# Altium schematic sheet lv_mcu_ios_100.SchDoc
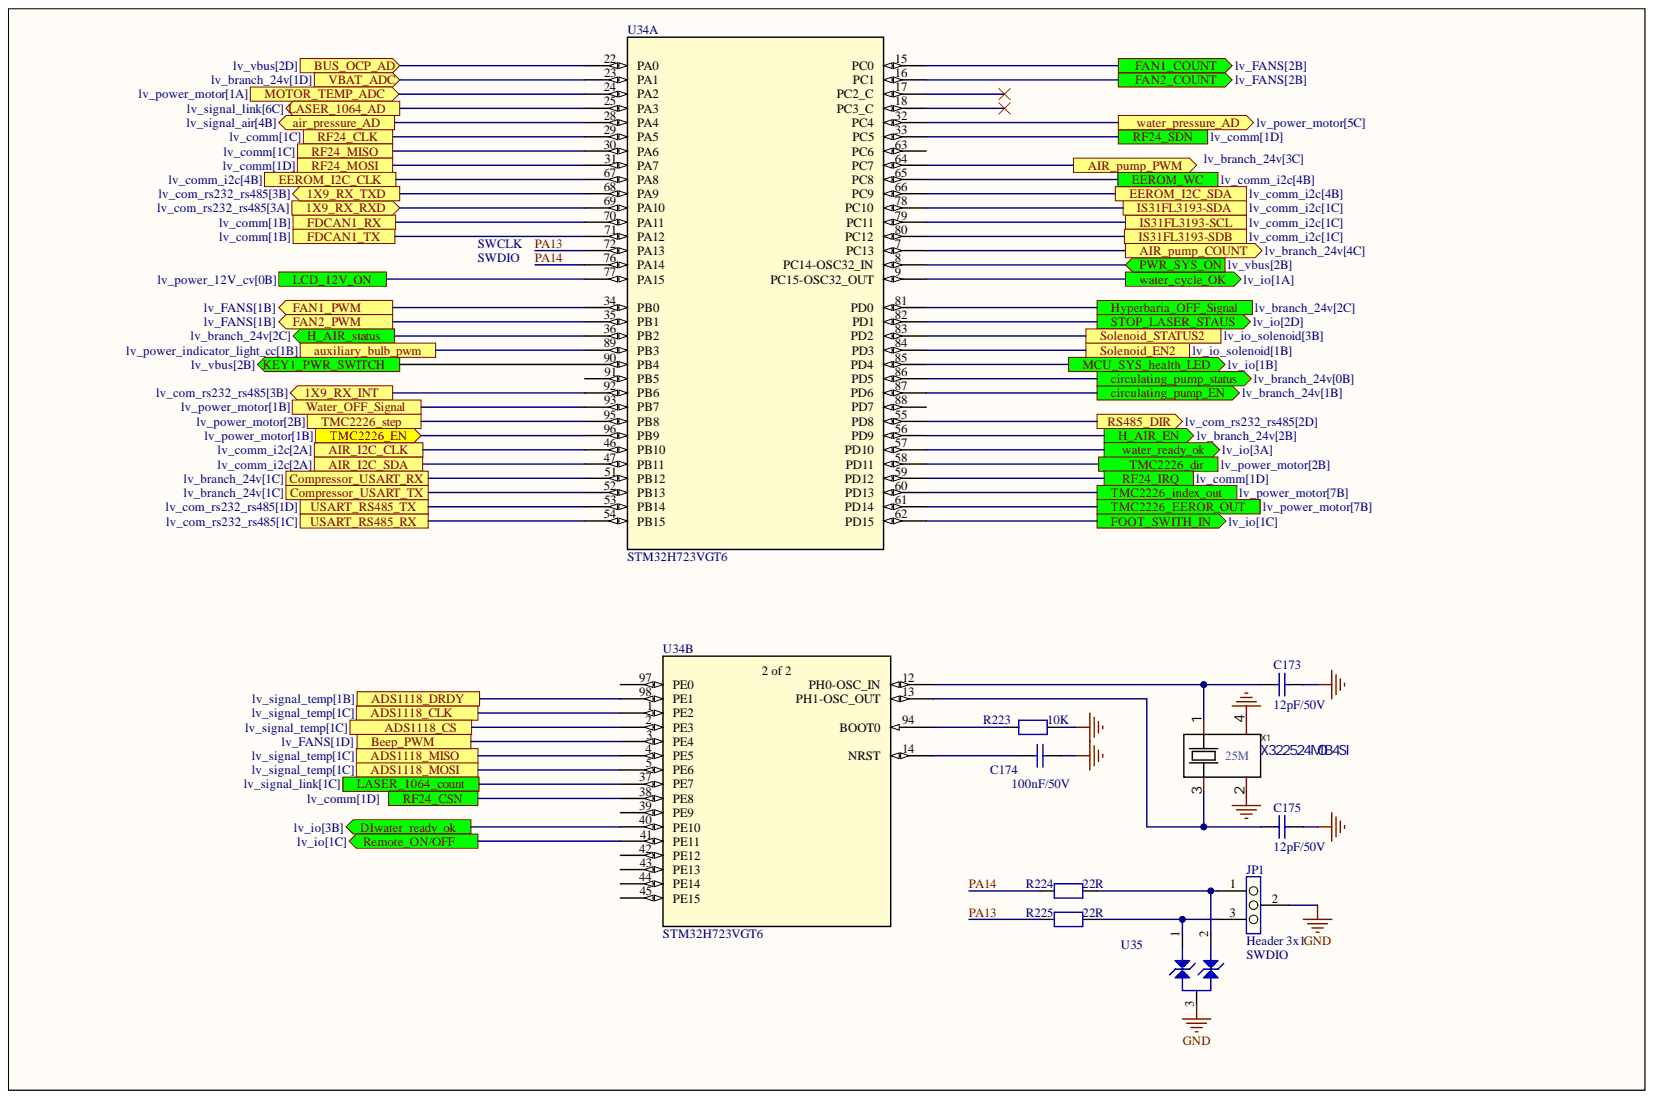
[diagram p0: lv_mcu_ios_100.SchDoc]

## Parts
C173  12pF/50V  [C0402]
C174  100nF/50V  [C0402]
C175  12pF/50V  [C0402]
JP1  value Header 3x1  [CON_SAMTEC_TSW-103-07-F-S]  (hidden comment: Jumper_Header 3x1)
R223  10K  [R0402]
R224  22R  [R0402]
R225  22R  [R0402]
U34  STM32H723VGT6  [LQFP100_STM-L]  (parts A,B)
U35  ESDCAN03-2BWY  [DIO_SOT323]  (value not drawn)
X1  X322524MOB4SI  [CRYSTAL-SMD_4P-L3.2-W2.5-BL]

## Ports
1X9_RX_INT, 1X9_RX_RXD, 1X9_RX_TXD, ADS1118_CLK, ADS1118_CS, ADS1118_DRDY, ADS1118_MISO, ADS1118_MOSI, AIR_I2C_CLK, AIR_I2C_SDA, air_pressure_AD, AIR_pump_COUNT, AIR_pump_PWM, auxiliary_bulb_pwm, Beep_PWM, BUS_OCP_AD, circulating_pump_EN, circulating_pump_status, Compressor_USART_RX, Compressor_USART_TX, DIwater_ready_ok, EEROM_I2C_CLK, EEROM_I2C_SDA, EEROM_WC, FAN1_COUNT, FAN1_PWM, FAN2_COUNT, FAN2_PWM, FDCAN1_RX, FDCAN1_TX, FOOT_SWITH_IN, H_AIR_EN, H_AIR_status, Hyperbaria_OFF_Signal, IS31FL3193-SCL, IS31FL3193-SDA, IS31FL3193-SDB, KEY1_PWR_SWITCH, LASER_1064_AD, LASER_1064_count, LCD_12V_ON, MCU_SYS_health_LED, MOTOR_TEMP_ADC, PWR_SYS_ON, Remote_ON/OFF, RF24_CLK, RF24_CSN, RF24_IRQ, RF24_MISO, RF24_MOSI, RF24_SDN, RS485_DIR, Solenoid_EN2, Solenoid_STATUS2, STOP_LASER_STAUS, TMC2226_dir, TMC2226_EEROR_OUT, TMC2226_EN, TMC2226_index_out, TMC2226_step, USART_RS485_RX, USART_RS485_TX, VBAT_ADC, water_cycle_OK, Water_OFF_Signal, water_pressure_AD, water_ready_ok

## Nets
GND: C173.1 C174.1 C175.1 JP1.2 R223.2 U35.3 X1.2 X1.4
1X9_RX_INT: U34.92(PB6) ; port 1X9_RX_INT
1X9_RX_RXD: U34.69(PA10) ; port 1X9_RX_RXD
1X9_RX_TXD: U34.68(PA9) ; port 1X9_RX_TXD
ADS1118_CLK: U34.1(PE2) ; port ADS1118_CLK
ADS1118_CS: U34.2(PE3) ; port ADS1118_CS
ADS1118_DRDY: U34.98(PE1) ; port ADS1118_DRDY
ADS1118_MISO: U34.4(PE5) ; port ADS1118_MISO
ADS1118_MOSI: U34.5(PE6) ; port ADS1118_MOSI
AIR_I2C_CLK: U34.46(PB10) ; port AIR_I2C_CLK
AIR_I2C_SDA: U34.47(PB11) ; port AIR_I2C_SDA
air_pressure_AD: U34.28(PA4) ; port air_pressure_AD
AIR_pump_COUNT: U34.7(PC13) ; port AIR_pump_COUNT
AIR_pump_PWM: U34.64(PC7) ; port AIR_pump_PWM
auxiliary_bulb_pwm: U34.89(PB3) ; port auxiliary_bulb_pwm
Beep_PWM: U34.3(PE4) ; port Beep_PWM
BUS_OCP_AD: U34.22(PA0) ; port BUS_OCP_AD
circulating_pump_EN: U34.87(PD6) ; port circulating_pump_EN
circulating_pump_status: U34.86(PD5) ; port circulating_pump_status
Compressor_USART_RX: U34.51(PB12) ; port Compressor_USART_RX
Compressor_USART_TX: U34.52(PB13) ; port Compressor_USART_TX
DIwater_ready_ok: U34.40(PE10) ; port DIwater_ready_ok
EEROM_I2C_CLK: U34.67(PA8) ; port EEROM_I2C_CLK
EEROM_I2C_SDA: U34.66(PC9) ; port EEROM_I2C_SDA
EEROM_WC: U34.65(PC8) ; port EEROM_WC
FAN1_COUNT: U34.15(PC0) ; port FAN1_COUNT
FAN1_PWM: U34.34(PB0) ; port FAN1_PWM
FAN2_COUNT: U34.16(PC1) ; port FAN2_COUNT
FAN2_PWM: U34.35(PB1) ; port FAN2_PWM
FDCAN1_RX: U34.70(PA11) ; port FDCAN1_RX
FDCAN1_TX: U34.71(PA12) ; port FDCAN1_TX
FOOT_SWITH_IN: U34.62(PD15) ; port FOOT_SWITH_IN
H_AIR_EN: U34.56(PD9) ; port H_AIR_EN
H_AIR_status: U34.36(PB2) ; port H_AIR_status
Hyperbaria_OFF_Signal: U34.81(PD0) ; port Hyperbaria_OFF_Signal
IS31FL3193-SCL: U34.79(PC11) ; port IS31FL3193-SCL
IS31FL3193-SDA: U34.78(PC10) ; port IS31FL3193-SDA
IS31FL3193-SDB: U34.80(PC12) ; port IS31FL3193-SDB
KEY1_PWR_SWITCH: U34.90(PB4) ; port KEY1_PWR_SWITCH
LASER_1064_AD: U34.25(PA3) ; port LASER_1064_AD
LASER_1064_count: U34.37(PE7) ; port LASER_1064_count
LCD_12V_ON: U34.77(PA15) ; port LCD_12V_ON
MCU_SYS_health_LED: U34.85(PD4) ; port MCU_SYS_health_LED
MOTOR_TEMP_ADC: U34.24(PA2) ; port MOTOR_TEMP_ADC
PA13: R225.1 U34.72(PA13)
PA14: R224.1 U34.76(PA14)
PWR_SYS_ON: U34.8(PC14-OSC32_IN) ; port PWR_SYS_ON
Remote_ON/OFF: U34.41(PE11) ; port Remote_ON/OFF
RF24_CLK: U34.29(PA5) ; port RF24_CLK
RF24_CSN: U34.38(PE8) ; port RF24_CSN
RF24_IRQ: U34.59(PD12) ; port RF24_IRQ
RF24_MISO: U34.30(PA6) ; port RF24_MISO
RF24_MOSI: U34.31(PA7) ; port RF24_MOSI
RF24_SDN: U34.33(PC5) ; port RF24_SDN
RS485_DIR: U34.55(PD8) ; port RS485_DIR
Solenoid_EN2: U34.84(PD3) ; port Solenoid_EN2
Solenoid_STATUS2: U34.83(PD2) ; port Solenoid_STATUS2
STOP_LASER_STAUS: U34.82(PD1) ; port STOP_LASER_STAUS
TMC2226_dir: U34.58(PD11) ; port TMC2226_dir
TMC2226_EEROR_OUT: U34.61(PD14) ; port TMC2226_EEROR_OUT
TMC2226_EN: U34.96(PB9) ; port TMC2226_EN
TMC2226_index_out: U34.60(PD13) ; port TMC2226_index_out
TMC2226_step: U34.95(PB8) ; port TMC2226_step
USART_RS485_RX: U34.54(PB15) ; port USART_RS485_RX
USART_RS485_TX: U34.53(PB14) ; port USART_RS485_TX
VBAT_ADC: U34.23(PA1) ; port VBAT_ADC
water_cycle_OK: U34.9(PC15-OSC32_OUT) ; port water_cycle_OK
Water_OFF_Signal: U34.93(PB7) ; port Water_OFF_Signal
water_pressure_AD: U34.32(PC4) ; port water_pressure_AD
water_ready_ok: U34.57(PD10) ; port water_ready_ok
NetC173_2: C173.2 U34.12(PH0-OSC_IN) X1.1
NetC174_2: C174.2 U34.14(NRST)
NetC175_2: C175.2 U34.13(PH1-OSC_OUT) X1.3
NetJP1_1: JP1.1 R224.2 U35.2
NetJP1_3: JP1.3 R225.2 U35.1
NetR223_1: R223.1 U34.94(BOOT0)

Not connected: U34 17(PC2_C) 18(PC3_C) 39(PE9) 42(PE12) 43(PE13) 44(PE14) 45(PE15) 63(PC6) 88(PD7) 91(PB5) 97(PE0)

## Library data of the parts
C173 C175 = MCD-00674: 50V,5%,C0G; Manufacturer: muRata; Manufacturer_Part_Number: GRM1555C1H120JA01D; Database Table Name: MCD_PARTS$; Component Kind: Standard; Library Name: MCD_PARTS_database.DbLib
  C175: ComponentLink1Description: Datasheet; ComponentLink1URL: http://tunmcdsvn.tun.st.com/Component_Datasheet_Library/Trunk/Capacitors/Yageo_Capacitor_NP0_16V-to-50V
C174 = MCD-00102: X7R, 10%; Manufacturer: YAGEO; Manufacturer_Part_Number: GRM155R71H104KE14D; Database Table Name: MCD_PARTS$; Component Kind: Standard; Library Name: MCD_PARTS_database.DbLib
JP1 = MCD-00111: Header, 3x1, 2,54mm, VR, TH, Height 5.84mm/2.54mm; Manufacturer: SAMTEC; Manufacturer_Part_Number: TSW-103-07-F-S; Part_Type: Connector; Initial_Board_Reference: MB1262; Item Type: Item; Path: ws/MCD_ApplicationBoards/Lists/MCDPARTSdatabase; Provided_By: SUBCO; Component Kind: Standard; ComponentLink1Description: Datasheet; ComponentLink1URL: http://tunmcdsvn.tun.st.com/Component_Datasheet_Library/Trunk/Connectors/Samtec_TSW-HTSW-series; Internal_Part_Number: MCD-00111; Library Name: MB1397.IntLib; Status: Released
R223 = RES: 1%; Component Kind: Standard; Library Name: HiPin_Library_AD.IntLib; Value: 10K
R224 R225 = RES: 1%; Value: 10K; Component Kind: Standard; Library Name: HiPin_Library_AD.IntLib
U34 = STM32H723VGT6: No Description Available; Mfr_Name: STMicroelectronics; Component Kind: Standard; Library Name: HiPin_Library_AD.IntLib; RefDes: RefDes; Type: Type
U35 = MCD-01228: 极性双向,反向截止电压(Vrwm)24V,钳位电压41V  ,峰值脉冲电流(Ipp)3.7A@8/20us; Manufacturer: STMICROELECTRONICS; Database Table Name: MCD_PARTS$; Component Kind: Standard; Library Name: MCD_PARTS_database.DbLib; Manufacturer_Part_Number: ESDCAN03-2BWY
X1 = X322524MOB4SI: 24MHz 10ppm 12pF; Manufacturer: YXC(扬兴晶振); Add into BOM: yes; Crystal Type: 贴片无源晶振; Frequency Stability: ±30ppm; Component Kind: Standard; Convert to PCB: yes; Datasheet: https://atta.szlcsc.com/upload/public/pdf/source/20210329/C112971_1F611BDC486E193C1706F6D8EB5AA189.pdf; Device: X322524MOB4SI; Frequency Tolerance: ±10ppm; JLCPCB Part Class: Extended Part; LCSC Part Name: 24MHz ±10ppm 12pF YSX321SL; Library Name: HiPin_Library_AD.IntLib; Load Capacitance: 12pF; Manufacturer Part: X322524MOB4SI; Name: 24MHz; Operating Temperature: -40℃~+85℃; PCB3D: OSC-SMD_4P-L3.2-W2.5-H1.0; Supplier: LCSC; Supplier Footprint: SMD3225-4P; Supplier Part: C70590; Symbol: X322524MOB4SI; Value: 24MHz
